# Altium schematic sheet GPS.SchDoc(GPS)
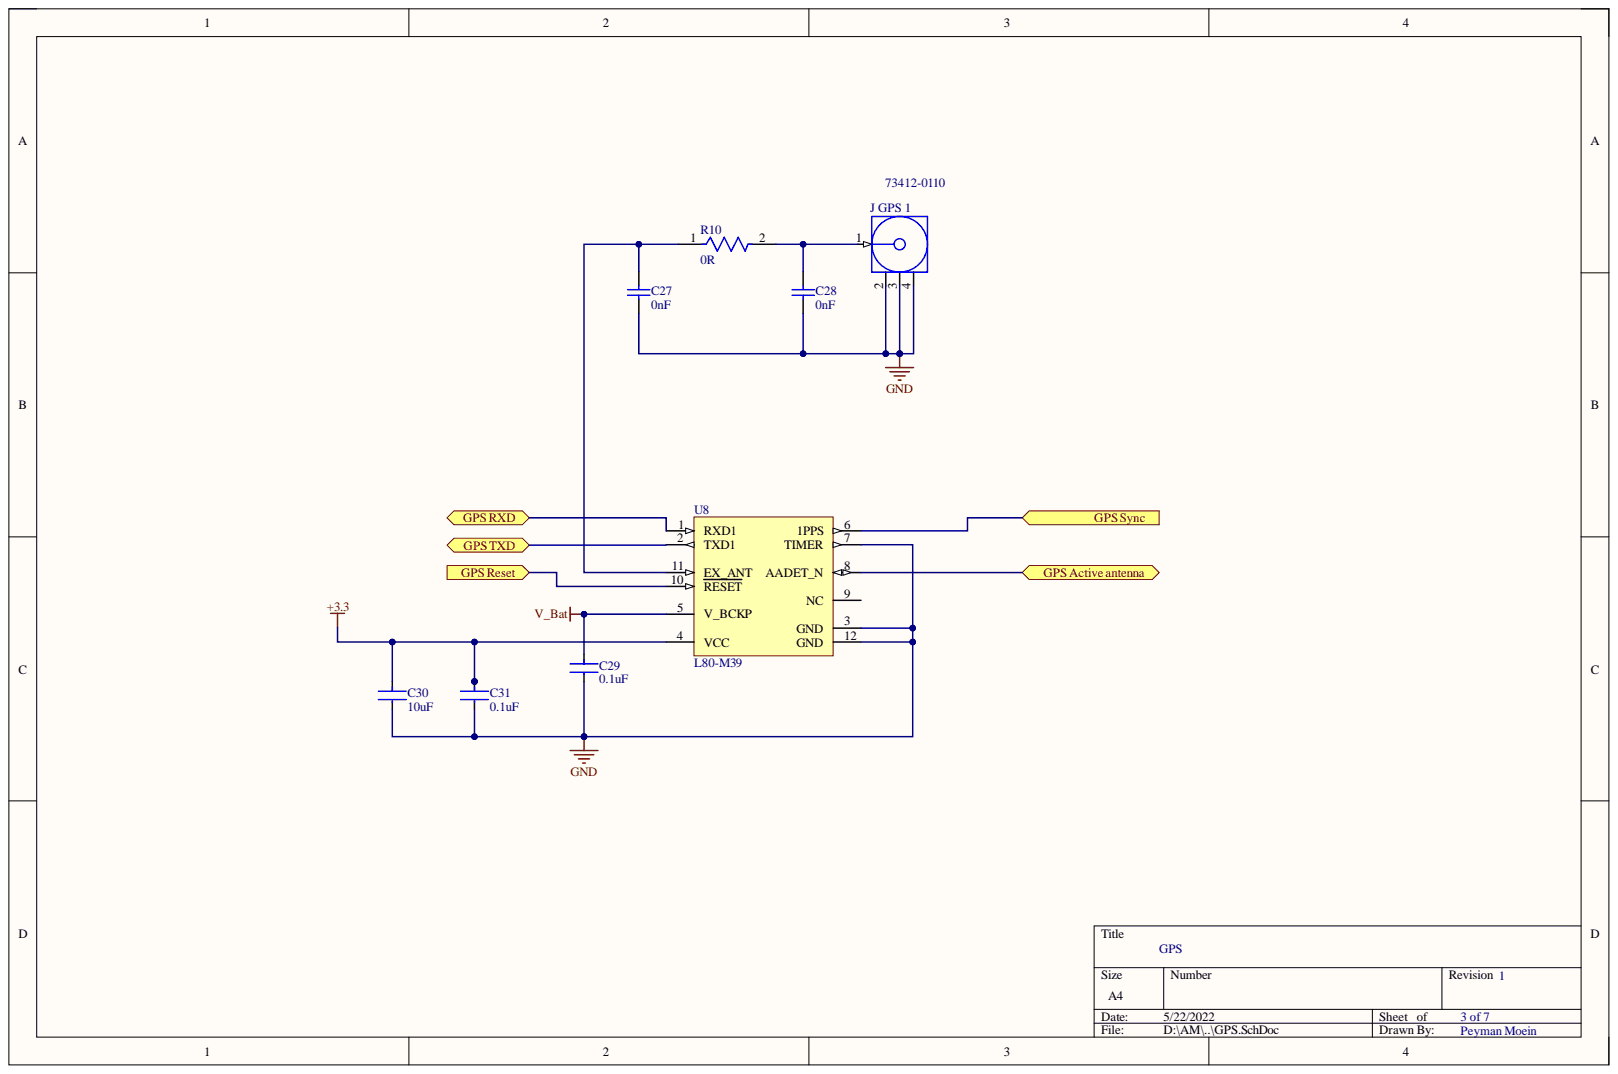
[diagram p0: GPS.SchDoc]

## Parts
C27  0nF  [CAPC2013X70X45NL10T25]
C28  0nF  [CAPC2013X70X45NL10T25]
C29  0.1uF  [FP-C0805C-DN-MFG]
C30  10uF  [FP-GRM21B-0_1-IPC_C]
C31  0.1uF  [FP-C0805C-DN-MFG]
J_GPS_1  73412-0110  [MOLX-73412-0110_V]
R10  0R  [FP-CRCW0805-e3-MFG]
U8  L80-M39  [QUEC-L80-M39-12_V]

## Ports
GPS Active antenna, GPS Reset, GPS RXD, GPS Sync, GPS TXD

## Nets
+3.3: C30.1 C31.1 U8.4(VCC)
GND: C27.1 C28.1 C29.2 C30.2 C31.2 J_GPS_1.2 J_GPS_1.3 J_GPS_1.4 U8.3(GND) U8.7(TIMER) U8.12(GND)
V_Bat: C29.1 U8.5(V_BCKP)
GPS Active antenna: U8.8(AADET_N) ; port GPS Active antenna
GPS Reset: U8.10(RESET) ; port GPS Reset
GPS RXD: U8.1(RXD1) ; port GPS RXD
GPS Sync: U8.6(1PPS) ; port GPS Sync
GPS TXD: U8.2(TXD1) ; port GPS TXD
NetC27_2: C27.2 R10.1 U8.11(EX_ANT)
NetC28_2: C28.2 J_GPS_1.1 R10.2

Not connected: U8 9(NC)

## Library data of the parts
C27 C28 = CMP-2007-00866-1: Manufacturer: Murata; Part Number: GRM2165C1H102JA01D; Component Kind: Standard; Library Name: Altium Content Vault
C29 C31 = CMP-2007-00279-2: CAP CER 0.1UF 50V X7R 0805; Manufacturer: KEMET; Manufacturer Part Number: C0805C104K5RACTU; Capacitance: 0.10 μF; Material: Ceramic; Temperature Coefficient: 30ppm/°C; Tolerance: 0.1; Value: 0.10 μF; Automotive: No; Category: Cap; Component Kind: Standard; ComponentLink2Description: Datasheet; ComponentLink2URL: https://content.kemet.com/datasheets/KEM_C1003_C0G_SMD.pdf; Device Class L1: Passive Components; Device Class L2: Capacitors; Device Class L3: Ceramic Capacitors; Digikey Description: CAP CER 0.1UF 50V X7R 0805; Height: 0.88mm; Ipc Land Pattern Name: CAPC200125X78; Lead Free: Yes; Library Name: Altium Content Vault; Mouser Description: Cap Ceramic 0.1uF 50V X7R 10% SMD 2.0 x 1.2 mm 125 C Paper T/R; Octopart: https://octopart.com/c0805c104k5ractu-kemet-143926; Package: C0805C-DN; RoHS: TRUE; Temperature: -55°C to +125°C; Temperature Characteristic: X7R; Temperature Range High: +125°C; Temperature Range Low: -55°C; Voltage Rating: 50 V
C30 = CMP-2007-03453-2: Chip Multilayer Ceramic Capacitors for General Purpose, 0805, 10uF, X5R, 15%, 10%, 16V; Capacitance: 10uF; Case Code (Imperial): 0805; Case Code (Metric): 2012; Case/Package: 0805; Component Kind: Standard; Depth: 1.25mm; Dielectric: X5R; Height: 1.25mm; Lead Free: Lead Free; Length: 2mm; Library Name: Altium Content Vault; Material: Ceramic; Max Operating Temperature: 85°C; Min Operating Temperature: -55°C; Mount: Surface Mount; Package Quantity: 1; Packaging: Tape and Reel; Radiation Hardening: No; REACH SVHC: No SVHC; Termination: SMD/SMT; Thickness: 0.053inch; Tolerance: 10%; Voltage: 16V; Voltage Rating: 16V; Voltage Rating (DC): 16V; Width: 1.25mm
J_GPS_1 = CMP-1676-00003-1: MCRF, PCB Vertical Jack Receptacle, 50 Ohm, 4-Pin SMD, RoHS, Tape and Reel; Frequency: 6 Hz; Gender: Female; Impedance: 50 Ohm; Mating Cycles: 30; Voltage Rating: 60 V; Component Kind: Standard; ComponentLink1Description: Manufacturer URL; ComponentLink1URL: http://www.molex.com/; ComponentLink2Description: Datasheet; ComponentLink2URL: http://www.molex.com/webdocs/datasheets/pdf/en-us/0734120110_RF_COAX_CONNECTORS.pdf; ComponentLink3Description: Package Specification; ComponentLink3URL: http://www.molex.com/pdm_docs/sd/734120110_sd.pdf; Contact Material: Bronze; Contact Plating: Gold; DatasheetVersion: 04/2016; Height: 1.25 mm; Length: 3 mm; Library Name: Altium Content Vault; Max Operating Temperature: 90 degC; Min Operating Temperature: -40 degC; Mount: Surface Mount; Mounting Technology: Surface Mount; Package Quantity: 5000; PackageDescription: 4-Leads SMD, Body 2.6 x 2.6 mm; PackageReference: 73412-0110; PackageVersion: Rev. C14, 07/2015; Packaging: Tape and Reel; Radiation Hardening: No; REACH SVHC: Unknown; RoHSCompliant: true; Voltage Rating (AC): 60 V; Width: 3 mm
R10 = CMP-02407-004193-1: RES Thick Film, 2.94Ω, 1%, 0.125W, 100ppm/°C, 0805; Max Power Dissipation: 125mW; Resistance: 2.94R; Voltage Rating: 150V; Case Code (Imperial): 0805; Case Code (Metric): 2012; Case/Package: 0805; Component Kind: Standard; Composition: Thick Film; Contact Plating: Tin; Depth: 1.25mm; Features: Automotive AEC-Q200; Height: 0.02inch; Lead Free: Lead Free; Length: 2mm; Library Name: Altium Content Vault; Max Operating Temperature: 155°C; Military Standard: Not; Min Operating Temperature: -55°C; Number of Terminations: 2; Package Quantity: 10; Packaging: Tape and Reel; Power Rating: 125mW; Radiation Hardening: No; Temperature Coefficient: 100ppm/°C; Termination: SMD/SMT; Tolerance: 1%; Weight: 0.000194oz; Width: 0.049inch
U8 = CMP-2000-05325-1: GPS Module L80 Hardware Design, 1575.42 MHz, 3 to 4.3 V, -40 to 85 degC, 12-Pin SMD, RoHS, Tape and Reel; Manufacturer: Quectel; Maximum Operating Supply Voltage: 4.3V; Minimum Operating Supply Voltage: 3V; Screening Level: ExtendedIndustrial; Typical Operating Supply Voltage: 3.3V; Component Kind: Standard; Datasheet URL: http://www.quectel.com/uploadimage/downlad/l80_hardware_design_v1.1.pdf; Datasheet Version: Rev. V1.1, 08/2013; Library Name: Altium Content Vault; Manufacturer URL: http://www.quectel.com/; Maximum Operating Frequency: 1575.42MHz; Mounting: SurfaceMount
